# Altium schematic sheet POWER.SchDoc(POWER)
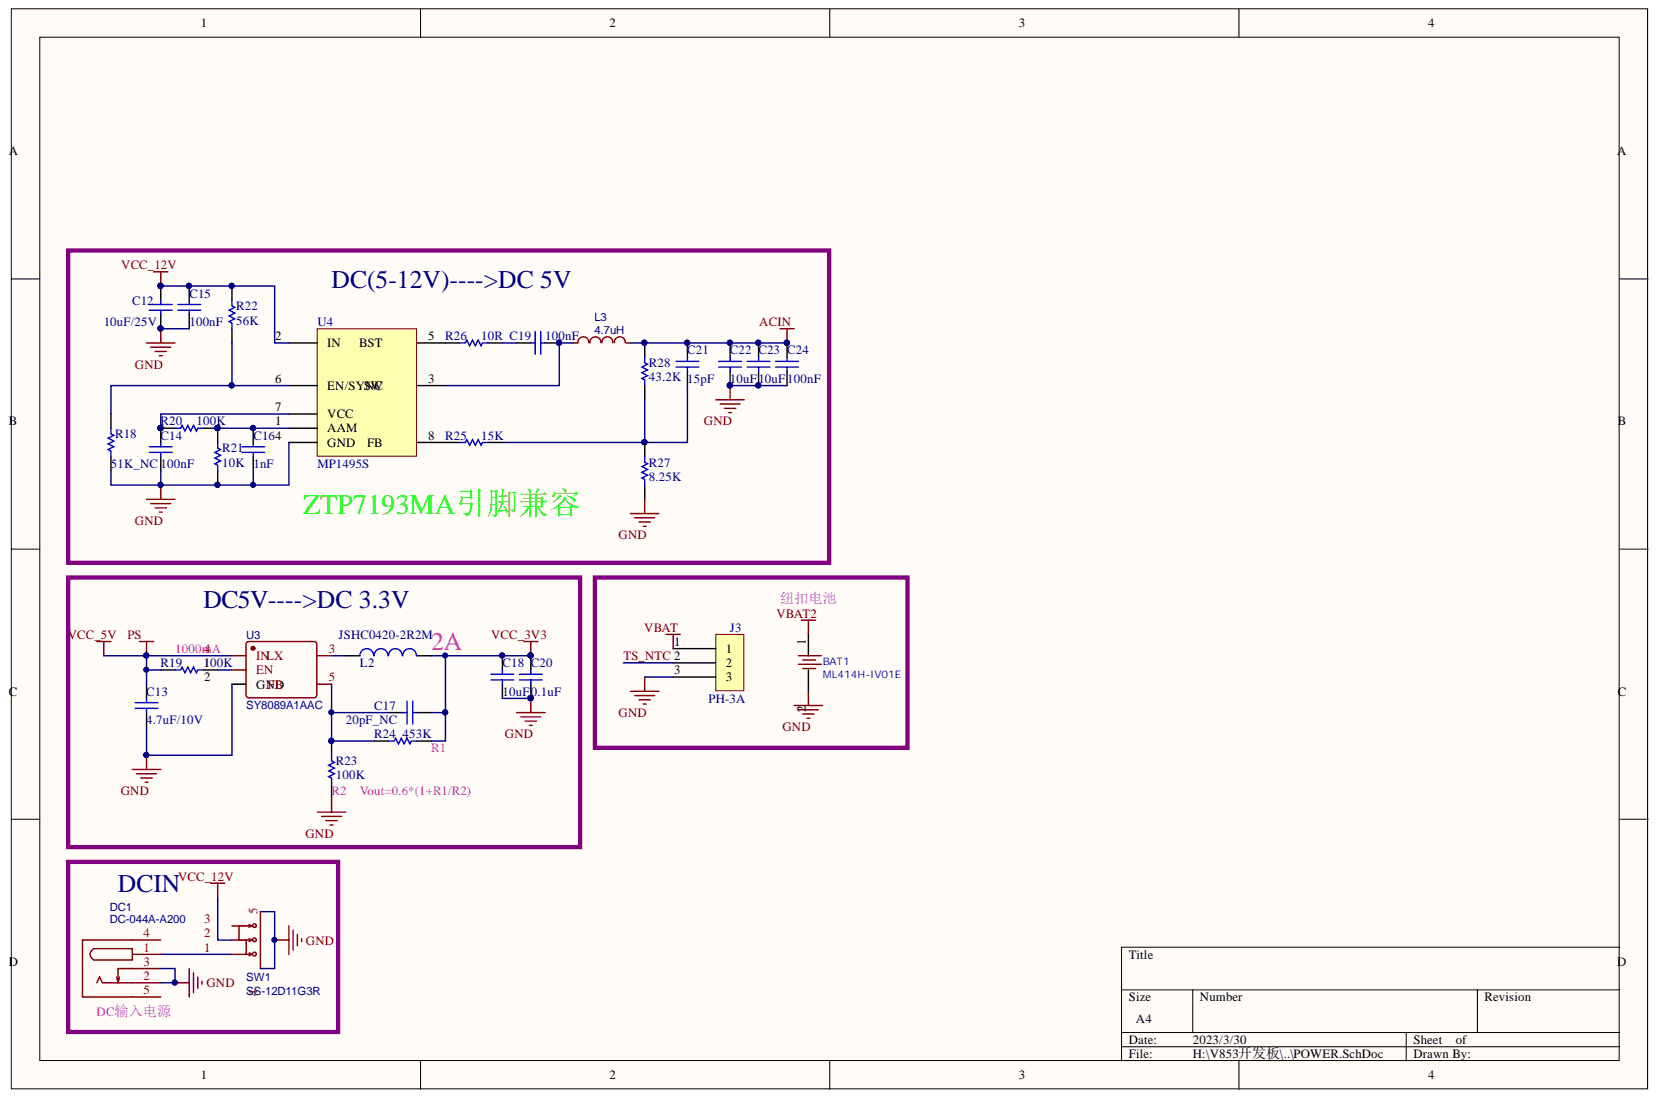
[diagram p0: POWER.SchDoc]

## Parts
BAT1  ML414H-IV01E  [BAT-SMD_ML414H-IV01E]
C12  10uF/25V  [0603]
C13  4.7uF/10V  [0402]
C14  100nF  [0402]
C15  100nF  [0402]
C16  1nF  [0402]
C17  20pF_NC  [0402]
C18  10uF  [0402]
C19  100nF  [0402]
C20  0.1uF  [0402]
C21  15pF  [0402]
C22  10uF  [0402]
C23  10uF  [0402]
C24  100nF  [0402]
DC1  DC-044A-A200  [DC-IN-TH_DC-044A-20A]
J3  PH-3A  [CONN-TH_PH-3A]
L2  JSHC0420-2R2M  [IND-SMD_L4.9-W4.5]
L3  4.7uH  [IND-SMD_L6.0-W6.0]
R18  51K_NC  [0402]
R19  100K  [0402]
R20  100K  [0402]
R21  10K  [0402]
R22  56K  [0402]
R23  100K  [0402]
R24  453K  [0402]
R25  15K  [0402]
R26  10R  [0402]
R27  8.25K  [0402]
R28  43.2K  [0402]
SW1  SS-12D11G3R  [SW-TH_SS-12D11L3]
U3  SY8089A1AAC  [SOT-23-5_L3.0-W1.7-P0.95-LS2.8-BL]
U4  MP1495S  [TSOT-23-8]

## Nets
ACIN: C21.1 C22.1 C23.1 C24.1 L3.2 R28.1
GND: BAT1.2 C12.2 C13.1 C14.2 C15.2 C16.2 C18.1 C20.1 C22.2 C23.2 C24.2 DC1.2 DC1.3 J3.3 R18.2 R21.2 R23.2 R27.2 SW1.4 SW1.5 U3.2(GND) U4.4(GND)
PS: C13.2 R19.2 U3.4(IN)
VBAT: J3.1
VBAT2: BAT1.1
VCC_3V3: C17.2 C18.2 C20.2 L2.2 R24.1
VCC_12V: C12.1 C15.1 R22.1 SW1.2 U4.2(IN)
TS_NTC: J3.2
NetC14_1: C14.1 R20.2 U4.7(VCC)
NetC16_1: C16.1 R20.1 R21.1 U4.1(AAM)
NetC17_1: C17.1 R23.1 R24.2 U3.5
NetC19_1: C19.1 R26.1
NetC19_2: C19.2 L3.1 U4.3
NetC21_2: C21.2 R25.1 R27.1 R28.2
NetDC1_1: DC1.1 SW1.1
NetL2_1: L2.1 U3.3
NetR18_1: R18.1 R22.2 U4.6(EN/SYNC)
NetR19_1: R19.1 U3.1(EN)
NetR25_2: R25.2 U4.8
NetR26_2: R26.2 U4.5

Not connected: DC1 4 5; SW1 3

## Library data of the parts
C12-C24 = Cap: Capacitor; PartNumber: CAP100NF; Component Kind: Standard; LatestRevisionDate: 17-Jul-2002; LatestRevisionNote: Re-released for DXP Platform.; Library Name: Miscellaneous Devices.IntLib; PackageReference: 0402; Published: 8-Jun-2000; Signal Integrity: Cap; Simulation: CAP; Publisher: Altium Limited
  C12: Value: 10uF/25V
  C13: Value: 4.7uF/10V
  C14 C15 C19 C24: Value: 100nF
  C16: Value: 1nF
  C17: Value: 20pF_NC
  C18 C22 C23: Value: 10uF
  C20: Value: 0.1uF
  C21: Value: 15pF
DC1 = DC-044A-A200: Contributor: lcsc; BOM_Manufacturer Part: DC-044A-A200; BOM_Supplier: LCSC; BOM_Supplier Part: C720575; Component Kind: Standard; spicePre: D; spiceSymbolName: DC-044A-A200
J3 = SIP3: Component Kind: Standard; Library Name: HM2.SchLib
L2 = Inductor: PartNumber: IND10UH; Code_IEC: 1005; Code_JEITA: 0402; Component Kind: Standard; Description: Inductor; JLC NO: C386778; LatestRevisionDate: 29-May-2009; LatestRevisionNote: IPC-7351 Footprint Added.; Library Name: Miscellaneous Devices.IntLib; PackageDescription: Chip Inductor; PackageReference: 0402-A; Published: 8-Jun-2000; Signal Integrity: Inductor; Simulation: INDUCTOR; Value: JSHC0420-2R2M; Publisher: Altium Limited
L3 = 4.7uH: BOM_Manufacturer: Sunltech Tech; BOM_Manufacturer Part: SLW6028S4R7MST; Contributor: LCSC; nameAlias: Inductance; BOM_JLCPCB Part Class: Extended Part; BOM_Supplier: LCSC; BOM_Supplier Part: C206281; Component Kind: Standard; spicePre: L; spiceSymbolName: SLW6028S4R7MST
R18 R20-R22 R25-R28 = Res1: Resistor; PartNumber: RES22R; Component Kind: Standard; LatestRevisionDate: 17-Jul-2002; LatestRevisionNote: Re-released for DXP Platform.; Library Name: Miscellaneous Devices.IntLib; PackageReference: 0402; Published: 8-Jun-2000; Signal Integrity: Res; Simulation: RESISTOR; Publisher: Altium Limited
  R18: Value: 51K_NC
  R20: Value: 100K
  R21: Value: 10K
  R22: Value: 56K
  R25: Value: 15K
  R26: Value: 10R
  R27: Value: 8.25K
  R28: Value: 43.2K
R19 R23 R24 = Res1: Resistor; PartNumber: RES2.2K; Component Kind: Standard; LatestRevisionDate: 17-Jul-2002; LatestRevisionNote: Re-released for DXP Platform.; Library Name: Miscellaneous Devices.IntLib; PackageReference: 0402; Published: 8-Jun-2000; Signal Integrity: Res; Simulation: RESISTOR; Publisher: Altium Limited
  R19 R23: Value: 100K
  R24: Value: 453K
SW1 = SS-12D11G3R: BOM_Manufacturer: XKB Connectivity; Contributor: LCSC; BOM_JLCPCB Part Class: Extended Part; BOM_Manufacturer Part: SS-12D11G3R; BOM_Supplier: LCSC; BOM_Supplier Part: C843362; Component Kind: Standard; spicePre: S; spiceSymbolName: SS-12D11G3R
U3 = SY8089A1AAC: BOM_Manufacturer: Silergy Corp; Contributor: LCSC; BOM_JLCPCB Part Class: Extended Part; BOM_Manufacturer Part: SY8089A1AAC; BOM_Supplier: LCSC; BOM_Supplier Part: C479074; Component Kind: Standard; Library Name: HM2.SchLib; spicePre: U; spiceSymbolName: SY8089A1AAC
BAT1 = ML414H-IV01E: Component Kind: Standard
U4 = MP1495S: Component Kind: Standard; Library Name: HM2.SchLib; Value: MP1495S
